# Altium schematic sheet F407_DISC.SchDoc(F407_DISC)
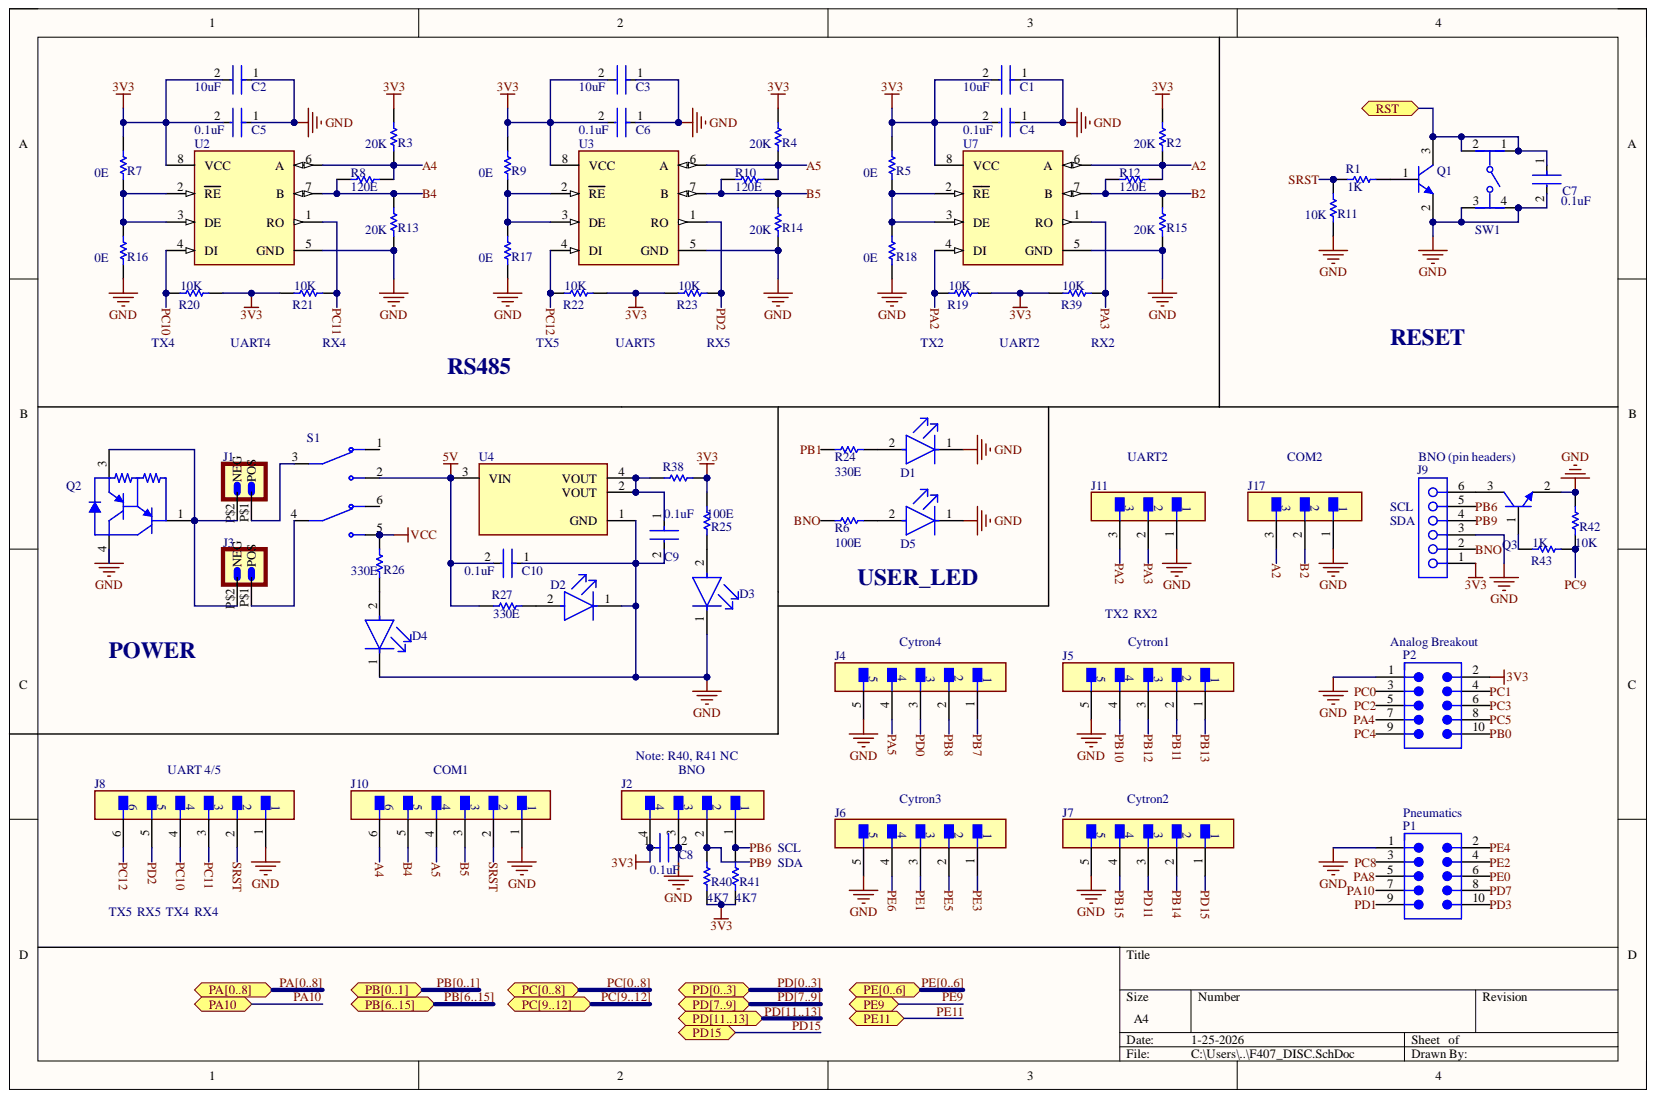
[diagram p0: F407_DISC.SchDoc]

## Parts
C1  CC0805KRX7R9BB104  [FP-CC0805-0_85-MFG]  (value not drawn)
C2  CC0805KRX7R9BB104  [FP-CC0805-0_85-MFG]  (value not drawn)
C3  CC0805KRX7R9BB104  [FP-CC0805-0_85-MFG]  (value not drawn)
C4  CC0805KRX7R9BB104  [FP-CC0805-0_85-MFG]  (value not drawn)
C5  CC0805KRX7R9BB104  [FP-CC0805-0_85-MFG]  (value not drawn)
C6  CC0805KRX7R9BB104  [FP-CC0805-0_85-MFG]  (value not drawn)
C7  CC0805KRX7R9BB104  [FP-CC0805-0_85-MFG]  (value not drawn)
C8  CC0805KRX7R9BB104  [FP-CC0805-0_85-MFG]  (value not drawn)
C9  CC0805KRX7R9BB104  [FP-CC0805-0_85-MFG]  (value not drawn)
C10  CC0805KRX7R9BB104  [FP-CC0805-0_85-MFG]  (value not drawn)
D1  HSMS-C150  [FP-HSMS-C150-MFG]  (value not drawn)
D2  HSMS-C150  [FP-HSMS-C150-MFG]  (value not drawn)
D3  HSMS-C150  [FP-HSMS-C150-MFG]  (value not drawn)
D4  HSMS-C150  [FP-HSMS-C150-MFG]  (value not drawn)
D5  HSMS-C150  [FP-HSMS-C150-MFG]  (value not drawn)
J1  XT30UPB-M  [XT30UPB-M]  (value not drawn)
J2  B4B-XH-A(LF)(SN)  [FP-B4B-XH-A_LF_SN-MFG]  (value not drawn)
J3  XT30UPB-M  [XT30UPB-M]  (value not drawn)
J4  B5B-XH-AM(LF)(SN)  [FP-B5B-XH-AM_LF_SN-MFG]  (value not drawn)
J5  B5B-XH-AM(LF)(SN)  [FP-B5B-XH-AM_LF_SN-MFG]  (value not drawn)
J6  B5B-XH-AM(LF)(SN)  [FP-B5B-XH-AM_LF_SN-MFG]  (value not drawn)
J7  B5B-XH-AM(LF)(SN)  [FP-B5B-XH-AM_LF_SN-MFG]  (value not drawn)
J8  B6B-XH-A(LF)(SN)  [FP-B6B-XH-A_LF_SN-MFG]  (value not drawn)
J9  61300611821  [61300611821]  (value not drawn)
J10  B6B-XH-A(LF)(SN)  [FP-B6B-XH-A_LF_SN-MFG]  (value not drawn)
J11  B3B-XH-AM(LF)(SN)  [FP-B3B-XH-AM_LF_SN-MFG]  (value not drawn)
J17  B3B-XH-AM(LF)(SN)  [FP-B3B-XH-AM_LF_SN-MFG]  (value not drawn)
P1  61201021621  [61201021621]  (value not drawn)
P2  61201021621  [61201021621]  (value not drawn)
Q1  MMBTA42LT1G  [ONSC-SOT-23-3-318-08_V]  (value not drawn)
Q2  MJD127G  [ONSC-DPAK-3-369C-01_V]  (value not drawn)
Q3  MMBTA42LT1G  [ONSC-SOT-23-3-318-08_V]  (value not drawn)
R1  RC0805FR-071KL  [RESC2013X60X35ML10T20]  (value not drawn)
R2  RC0805FR-071KL  [RESC2013X60X35ML10T20]  (value not drawn)
R3  RC0805FR-071KL  [RESC2013X60X35ML10T20]  (value not drawn)
R4  RC0805FR-071KL  [RESC2013X60X35ML10T20]  (value not drawn)
R5  RC0805FR-071KL  [RESC2013X60X35ML10T20]  (value not drawn)
R6  RC0805FR-071KL  [RESC2013X60X35ML10T20]  (value not drawn)
R7  RC0805FR-071KL  [RESC2013X60X35ML10T20]  (value not drawn)
R8  RC0805FR-071KL  [RESC2013X60X35ML10T20]  (value not drawn)
R9  RC0805FR-071KL  [RESC2013X60X35ML10T20]  (value not drawn)
R10  RC0805FR-071KL  [RESC2013X60X35ML10T20]  (value not drawn)
R11  RC0805FR-071KL  [RESC2013X60X35ML10T20]  (value not drawn)
R12  RC0805FR-071KL  [RESC2013X60X35ML10T20]  (value not drawn)
R13  RC0805FR-071KL  [RESC2013X60X35ML10T20]  (value not drawn)
R14  RC0805FR-071KL  [RESC2013X60X35ML10T20]  (value not drawn)
R15  RC0805FR-071KL  [RESC2013X60X35ML10T20]  (value not drawn)
R16  RC0805FR-071KL  [RESC2013X60X35ML10T20]  (value not drawn)
R17  RC0805FR-071KL  [RESC2013X60X35ML10T20]  (value not drawn)
R18  RC0805FR-071KL  [RESC2013X60X35ML10T20]  (value not drawn)
R19  RC0805FR-071KL  [RESC2013X60X35ML10T20]  (value not drawn)
R20  RC0805FR-071KL  [RESC2013X60X35ML10T20]  (value not drawn)
R21  RC0805FR-071KL  [RESC2013X60X35ML10T20]  (value not drawn)
R22  RC0805FR-071KL  [RESC2013X60X35ML10T20]  (value not drawn)
R23  RC0805FR-071KL  [RESC2013X60X35ML10T20]  (value not drawn)
R24  RC0805FR-071KL  [RESC2013X60X35ML10T20]  (value not drawn)
R25  RC0805FR-071KL  [RESC2013X60X35ML10T20]  (value not drawn)
R26  RC0805FR-071KL  [RESC2013X60X35ML10T20]  (value not drawn)
R27  RC0805FR-071KL  [RESC2013X60X35ML10T20]  (value not drawn)
R38  RC0805FR-071KL  [RESC2013X60X35ML10T20]  (value not drawn)
R39  RC0805FR-071KL  [RESC2013X60X35ML10T20]  (value not drawn)
R40  RC0805FR-071KL  [RESC2013X60X35ML10T20]  (value not drawn)
R41  RC0805FR-071KL  [RESC2013X60X35ML10T20]  (value not drawn)
R42  RC0805FR-071KL  [RESC2013X60X35ML10T20]  (value not drawn)
R43  RC0805FR-071KL  [RESC2013X60X35ML10T20]  (value not drawn)
S1  TL2285EE  [SW_TL2285EE]  (value not drawn)
SW1  1571634-2  [FP-1571634-2-MFG]  (value not drawn)
U2  MAX485ESA+T  [FP-S8-2-MFG]  (value not drawn)
U3  MAX485ESA+T  [FP-S8-2-MFG]  (value not drawn)
U4  LD1117S33CTR  [FP-SOT-223-0046067_14-MFG]  (value not drawn)
U7  MAX485ESA+T  [FP-S8-2-MFG]  (value not drawn)

## Ports
PA0, PA1, PA2, PA3, PA4, PA5, PA6, PA7, PA8, PA10, PA[0..8], PB0, PB1, PB6, PB7, PB8, PB9, PB10, PB11, PB12, PB13, PB14, PB15, PB[0..1], PB[6..15], PC0, PC1, PC2, PC3, PC4, PC5, PC6, PC7, PC8, PC9, PC10, PC11, PC12, PC[0..8], PC[9..12], PD0, PD1, PD2, PD3, PD7, PD8, PD9, PD11, PD12, PD13, PD15, PD[0..3], PD[7..9], PD[11..13], PE0, PE1, PE2, PE3, PE4, PE5, PE6, PE9, PE11, PE[0..6], RST

## Nets
3V3: C1.2 C2.2 C3.2 C4.2 C5.2 C6.2 C8.1 J2.4 J9.1 P2.2 R2.1 R3.1 R4.1 R5.2 R7.2 R9.2 R19.1 R20.1 R21.2 R22.1 R23.2 R25.1 R38.2 R39.2 R40.1 R41.1 U2.8(VCC) U3.8(VCC) U7.8(VCC)
5V: C10.2 R27.1 S1.2 U4.3(VIN)
GND: C1.1 C2.1 C3.1 C4.1 C5.1 C6.1 C7.2 C8.2 C9.2 C10.1 D1.1 D2.1 D3.1 D4.1 D5.1 J2.3 J4.5 J5.5 J6.5 J7.5 J8.1 J9.3 J10.1 J11.1 J17.1 P1.1 P2.1 Q1.2 Q2.4 Q3.2 R11.1 R13.2 R14.2 R15.2 R16.1 R17.1 R18.1 R42.1 SW1.3 SW1.4 U2.5(GND) U3.5(GND) U4.1(GND) U7.5(GND)
VCC: R26.2 S1.5
A2: J17.3 R2.2 R12.1 U7.6(A)
A4: J10.6 R3.2 R8.1 U2.6(A)
A5: J10.4 R4.2 R10.1 U3.6(A)
B2: J17.2 R12.2 R15.1 U7.7(B)
B4: J10.5 R8.2 R13.1 U2.7(B)
B5: J10.3 R10.2 R14.1 U3.7(B)
BNO: J9.2 R6.1
PA2: J11.3 R19.2 U7.4(DI) ; port PA[0..8]  [also labelled PA]
PA3: J11.2 R39.1 U7.1(RO) ; port PA[0..8]  [also labelled PA]
PA4: P2.7 ; port PA[0..8]  [also labelled PA]
PA5: J4.4 ; port PA[0..8]  [also labelled PA]
PA8: P1.5 ; port PA[0..8]  [also labelled PA]
PA10: P1.7 ; port PA10
PB0: P2.10 ; port PB[0..1]  [also labelled PB]
PB1: R24.1 ; port PB[0..1]  [also labelled PB]
PB6: J2.1 J9.5 R41.2 ; port PB[6..15]  [also labelled PB]
PB7: J4.1 ; port PB[6..15]  [also labelled PB]
PB8: J4.2 ; port PB[6..15]  [also labelled PB]
PB9: J2.2 J9.4 R40.2 ; port PB[6..15]  [also labelled PB]
PB10: J5.4 ; port PB[6..15]  [also labelled PB]
PB11: J5.2 ; port PB[6..15]  [also labelled PB]
PB12: J5.3 ; port PB[6..15]  [also labelled PB]
PB13: J5.1 ; port PB[6..15]  [also labelled PB]
PB14: J7.2 ; port PB[6..15]  [also labelled PB]
PB15: J7.4 ; port PB[6..15]  [also labelled PB]
PC0: P2.3 ; port PC[0..8]  [also labelled PC]
PC1: P2.4 ; port PC[0..8]  [also labelled PC]
PC2: P2.5 ; port PC[0..8]  [also labelled PC]
PC3: P2.6 ; port PC[0..8]  [also labelled PC]
PC4: P2.9 ; port PC[0..8]  [also labelled PC]
PC5: P2.8 ; port PC[0..8]  [also labelled PC]
PC8: P1.3 ; port PC[0..8]  [also labelled PC]
PC9: R42.2 R43.1 ; port PC[9..12]  [also labelled PC]
PC10: J8.4 R20.2 U2.4(DI) ; port PC[9..12]  [also labelled PC]
PC11: J8.3 R21.1 U2.1(RO) ; port PC[9..12]  [also labelled PC]
PC12: J8.6 R22.2 U3.4(DI) ; port PC[9..12]  [also labelled PC]
PD0: J4.3 ; port PD[0..3]  [also labelled PD]
PD1: P1.9 ; port PD[0..3]  [also labelled PD]
PD2: J8.5 R23.1 U3.1(RO) ; port PD[0..3]  [also labelled PD]
PD3: P1.10 ; port PD[0..3]  [also labelled PD]
PD7: P1.8 ; port PD[7..9]  [also labelled PD]
PD11: J7.3 ; port PD[11..13]  [also labelled PD]
PD15: J7.1 ; port PD15
PE0: P1.6 ; port PE[0..6]  [also labelled PE]
PE1: J6.3 ; port PE[0..6]  [also labelled PE]
PE2: P1.4 ; port PE[0..6]  [also labelled PE]
PE3: J6.1 ; port PE[0..6]  [also labelled PE]
PE4: P1.2 ; port PE[0..6]  [also labelled PE]
PE5: J6.2 ; port PE[0..6]  [also labelled PE]
PE6: J6.4 ; port PE[0..6]  [also labelled PE]
RST: C7.1 Q1.3 SW1.1 SW1.2 ; port RST
SRST: J8.2 J10.2 R1.1 R11.2
NetC9_1: C9.1 R38.1 U4.2(VOUT) U4.4(VOUT)
NetD1_2: D1.2 R24.2
NetD2_2: D2.2 R27.2
NetD3_2: D3.2 R25.2
NetD4_2: D4.2 R26.1
NetD5_2: D5.2 R6.2
NetJ1_P$1: J1.P$1 S1.3
NetJ1_P$2: J1.P$2 J3.P$2 Q2.1 Q2.3
NetJ3_P$1: J3.P$1 S1.4
NetJ9_6: J9.6 Q3.3
NetQ1_1: Q1.1 R1.2
NetQ3_1: Q3.1 R43.2
NetR5_1: R5.1 R18.2 U7.2(RE) U7.3(DE)
NetR7_1: R7.1 R16.2 U2.2(RE) U2.3(DE)
NetR9_1: R9.1 R17.2 U3.2(RE) U3.3(DE)
PA0: ; port PA[0..8]  [also labelled PA]
PA1: ; port PA[0..8]  [also labelled PA]
PA6: ; port PA[0..8]  [also labelled PA]
PA7: ; port PA[0..8]  [also labelled PA]
PB[0..1]: ; port PB[0..1]  [also labelled PB]
PB[6..15]: ; port PB[6..15]  [also labelled PB]
PC6: ; port PC[0..8]  [also labelled PC]
PC7: ; port PC[0..8]  [also labelled PC]
PC[0..8]: ; port PC[0..8]  [also labelled PC]
PC[9..12]: ; port PC[9..12]  [also labelled PC]
PD8: ; port PD[7..9]  [also labelled PD]
PD9: ; port PD[7..9]  [also labelled PD]
PD12: ; port PD[11..13]  [also labelled PD]
PD13: ; port PD[11..13]  [also labelled PD]
PD[0..3]: ; port PD[0..3]  [also labelled PD]
PD[7..9]: ; port PD[7..9]  [also labelled PD]
PD[11..13]: ; port PD[11..13]  [also labelled PD]
PE9: ; port PE9
PE11: ; port PE11

Not connected: S1 1 6

## Library data of the parts
C1-C10 = CMP-2100-03606-3: CAP CER 0.1UF 50V X7R 0805; Manufacturer: Yageo; Capacitance: 100 nF; Material: Ceramic; Tolerance: 0.1; Value: 100 nF; Automotive: No; Category: Cap; Component Kind: Standard; ComponentLink2Description: Datasheet; ComponentLink2URL: http://www.yageo.com/documents/recent/UPY-GPHC_Y5V_6.3V-to-50V_8.pdf; Device Class L1: Passive Components; Device Class L2: Capacitors; Device Class L3: Ceramic Capacitors; Digikey Description: CAP CER 0.1UF 50V X7R 0805; Footprint Url: http://www.yageo.com/exep/pages/download/literatures/UPY-C_GEN_24.pdf; Height: 0.95mm; Ipc Land Pattern Name: CAPC200125X85; Lead Free: Yes; Library Name: Altium Content Vault; Manufacturer Part Number: CC0805KRX7R9BB104; Mouser Description: Multilayer Ceramic Capacitors MLCC - SMD/SMT 100nF 50V X7R 10%; Octopart: https://octopart.com/cc0805krx7r9bb104-yageo-192993; Package: CC0805-0.85; RoHS: TRUE; Temperature: -30°C to +85°C; Temperature Characteristic: X7R; Temperature Range High: +85°C; Temperature Range Low: -30°C; Voltage Rating: 50 V
D1-D5 = CMP-21615-000001-1: LED RED DIFFUSED CHIP SMD; Dominant Wavelength: 626nm; Forward Current: 20mA; Forward Voltage: 2.1V; Lens Color: White; Lens Style: Rectangular; Luminous Intensity: 10mcd; Number of Elements: 1; Power Dissipation: 65mW; Reverse Voltage: 5V; Viewing Angle: 170°; Case Code (Imperial): 1206; Case Code (Metric): 3216; Case/Package: 1206; Color: Red; Component Kind: Standard; Depth: 1.6mm; Height: 1.1mm; Illumination Color: Red; Lead Free: Lead Free; Length: 3.2mm; Lens Transparency: Diffused; Library Name: Altium Content Vault; Max Operating Temperature: 85°C; Min Operating Temperature: -40°C; Mount: Surface Mount; Number of LEDs: 1; Number of Pins: 2; Package Quantity: 1; Packaging: Tape and Reel; Power Rating: 65mW; Radiation Hardening: No; REACH SVHC: No SVHC; Test Current: 20mA; Wavelength: 626nm; Width: 1.6mm
J1 J3 = XT30UPB-M: Socket; DC supply; XT30; male; PIN: 2; on PCBs; THT; yellow; 15A; 500V; MF: amass; Availability: Not in stock; Check_prices: https://www.snapeda.com/parts/XT30UPB-M/AMASS/view-part/?ref=eda; Component Kind: Standard; Library Name: XT30UPB-M.SchLib; MP: XT30UPB-M; Package: None; Price: None; SnapEDA_Link: https://www.snapeda.com/parts/XT30UPB-M/AMASS/view-part/?ref=snap
J2 = CMP-17439-000250-1: CONN HEADER VERT 4POS 2.5MM; Contact Resistance: 20mR; Current Rating: 3A; Gender: Male; Insulation Resistance: 1GR; Mated Stacking Heights: 9.8mm; Max Voltage Rating (AC): 250V; Number of Contacts: 4; Wire/Cable Gauge: 22AWG; Color: Natural; Component Kind: Standard; Connector Type: Header; Contact Finish - Mating: TIN; Contact Material: Brass; Contact Plating: Tin; Contact Shape: Square; Contact Type: Male Pin; Depth: 5.75mm; Fastening Type: Detent; Flammability Rating: UL94 V-0; Housing Color: White; Housing Material: Polyamide; Insulation Color: Natural; Insulation Material: Nylon; Lead Free: Lead Free; Lead Pitch: 2.5mm; Length: 12.4mm; Library Name: Altium Content Vault; Material: Polyamide; Max Current Rating: 3A; Max Operating Temperature: 85°C; Max Voltage Rating (DC): 250V; Min Operating Temperature: -25°C; Mount: Through Hole; Number of Positions: 4; Number of Rows: 1; Orientation: Straight; Package Quantity: 500; Packaging: Bulk; Pitch: 2.5mm; REACH SVHC: No SVHC; Series: XH; Termination: Solder; Voltage Rating: 250V; Voltage Rating (AC): 250V
J4-J7 = CMP-17439-000304-1: CONN HEADER VERT 5POS 2.5MM; Contact Resistance: 20mR; Mated Stacking Heights: 9.8mm; Max Current Rating: 3A; Max Voltage Rating (AC): 250V; Number of Positions: 5; Color: Green; Component Kind: Standard; Connector Type: Header; Contact Finish - Mating: TIN; Contact Material: Brass; Contact Plating: Copper; Contact Shape: Square; Contact Type: Male Pin; Depth: 5.75mm; Fastening Type: Detent; Flammability Rating: UL94 V-0; Housing Color: White; Housing Material: Polyamide; Insulation Color: Natural; Insulation Material: Nylon; Lead Free: Lead Free; Length: 14.9mm; Library Name: Altium Content Vault; Max Operating Temperature: 85°C; Min Operating Temperature: -25°C; Mount: Through Hole; Number of Rows: 1; Orientation: Straight; Packaging: Bulk; Pitch: 2.5mm; Series: XH; Termination: Solder; Voltage Rating: 250V
J8 J10 = CMP-17439-000076-1: CONN HEADER VERT 6POS 2.5MM; Component Kind: Standard; Library Name: Altium Content Vault
J9 = CMP-1502-01322-3: NexarPartId: 21642411; Contact Resistance: 20mΩ; Current Rating: 3A; Gender: Female; Operating Supply Voltage: 250V; Component Kind: Standard; Lead Pitch: 2.54mm; Library Name: Altium Content Vault; Number of Pins: 6; Orientation: Vertical; RoHS: Compliant
J11 J17 = CMP-17438-000009-1: CONN HEADER VERT 3POS 2.5MM; Connector Type: Male Pin; Contact Resistance: 20mR; Gender: Male; Max Current Rating: 3A; Max Voltage Rating (AC): 250V; Number of Positions: 3; Pitch: 0.098inch; Color: Natural; Component Kind: Standard; Contact Material: Brass; Contact Plating: Tin; Contact Type: Male Pin; Depth: 5.75mm; Fastening Type: Detent; Housing Color: White; Housing Material: Polyamide; Lead Free: Lead Free; Length: 9.9mm; Library Name: Altium Content Vault; Max Operating Temperature: 85°C; Min Operating Temperature: -25°C; Mount: Through Hole; Number of Rows: 1; Orientation: Straight; Packaging: Bulk; Series: XH; Termination: Press-Fit
P1 P2 = CMP-1502-00007-2: NexarPartId: 8917783; Connector Type: Male Pin; Contact Resistance: 20mΩ; Current Rating: 3A; Gender: Male; Insulation Resistance: 1GΩ; Number of Contacts: 10; Operating Supply Voltage: 250V; Pitch: 2.54mm; Color: Black; Component Kind: Standard; Contact Gender: Male; Contact Material: Copper; Contact Plating: Gold; Flammability Rating: UL94 V-0; Height: 8.85mm; Housing Material: PBT; Insulation Material: PBT; Lead Free: Lead Free; Lead Pitch: 2.54mm; Length: 20.36mm; Library Name: Altium Content Vault; Max Operating Temperature: 105°C; Min Operating Temperature: -40°C; Mount: Through Hole; Number of Pins: 10; Number of Positions: 10; Number of Rows: 2; Orientation: Vertical; REACH SVHC: Unknown; RoHS: Compliant; Row Spacing: 2.54mm; Termination: Solder; Voltage Rating: 250V; Voltage Rating (DC): 250V; Width: 20.36mm
Q1 Q3 = CMP-1048-00662-1: High Voltage Transistor, NPN Silicon, 3-Pin SOT-23, Pb-Free, Tape and Reel; Manufacturer: On Semiconductor; fT Min (MHz): 50; IC Continuous (A): 0.5; Component Kind: Standard; ComponentLink1Description: Manufacturer URL; ComponentLink1URL: http://www.onsemi.com/; ComponentLink2Description: Datasheet; ComponentLink2URL: http://www.onsemi.cn/pub_link/Collateral/MMBTA42LT1-D.PDF; ComponentLink3Description: Package Specification; ComponentLink3URL: http://www.onsemi.cn/pub_link/Collateral/318-08.PDF; DatasheetVersion: Rev. 12; Library Name: Altium Content Vault; Mounting Technology: Surface Mount; PackageDescription: 3-Pin Small Outline Transistor, Body 2.92 x 1.3 mm, Pitch 0.95 mm; PackageReference: SOT-23-3-318-08; PackageVersion: Rev. AP, 11/2009; Packing: Tape and Reel; PartNumber: MMBTA42LT1G; Polarity: NPN; RoHS: TRUE
Q2 = CMP-1047-00005-1: Complementary Darlington Power Transistor, 3-Pin DPAK, Pb-Free, Tube; Manufacturer: On Semiconductor; hFE Max (k): 12; IC Continuous (A): 8; Polarity: PNP; Component Kind: Standard; ComponentLink1Description: Manufacturer URL; ComponentLink1URL: http://www.onsemi.com/; ComponentLink2Description: Datasheet; ComponentLink2URL: http://www.onsemi.com/pub_link/Collateral/MJD122-D.PDF; ComponentLink3Description: Package Specification; ComponentLink3URL: http://www.onsemi.cn/pub_link/Collateral/369C.PDF; DatasheetVersion: Rev. 13; Library Name: Altium Content Vault; Mounting Technology: Surface Mount; PackageDescription: 3-Pin Flange Mount, Body 6.54 x 6.095 mm, Pitch 2.29 mm; PackageReference: DPAK-3-369C-01; PackageVersion: Rev. E, 02/2014; Packing: Tube; PartNumber: MJD127G; RoHS: TRUE
R1-R27 R38-R43 = CMP-2100-03671-1: Chip Resistor, 1 KOhm, +/- 1%, 125 mW, -55 to 155 degC, 0805 (2012 Metric), RoHS, Tape and Reel; Manufacturer: Yageo; Max Power Dissipation: 125mW; Resistance: 1KOhm; Temperature Coefficient: 100ppm/degreesC; Voltage Rating: 150V; Case Code (Imperial): 0805; Case Code (Metric): 2012; Case/Package: 0805; Component Kind: Standard; Composition: ThickFilm; Datasheet URL: http://www.yageo.com/NewPortal/yageodocoutput?fileName=/pdf/R-Chip/PYu-RC_Group_51_RoHS_L_5.pdf; Datasheet Version: Rev. 5, 10/2016; Depth: 1.25mm; Height: 0.024inch; Lead Free: LeadFree; Length: 0.079inch; Library Name: Altium Content Vault; Manufacturer URL: http://www.yageo.com/; Max Operating Temperature: 155degC; Military Standard: Not; Min Operating Temperature: -55degC; Number of Pins: 2; Number of Terminations: 2; Package Description: 2-Pin Surface Mounted Device, Body 2 x 1.25 mm; Package Quantity: 1; Package Reference: 0805; Packaging: TapeandReel; Power Rating: 125mW; REACH SVHC: Unknown; RoHSCompliant: true; Termination: SMD/SMT; Tolerance: 1%; Voltage Rating (DC): 150V; Width: 0.049inch
SW1 = CMP-03407-000008-1: SWITCH TACTILE SPST-NO 0.05A 24V; Actuator Color: Natural; Actuator Length: 0.094inch; Actuator Material: Rubber; Actuator Type: Standard; Contact Current Rating: 50mA; Illumination Type: Illuminated; Max Voltage Rating (DC): 12V; Mechanical Life: 5000000Cycles; Operating Force: 160gf; Switch Function: Off-Mom; Throw Configuration: SPST; Case/Package: SMD/SMT; Component Kind: Standard; Contact Material: Stainless Steel; Contact Plating: Silver; Current Rating: 50mA; Depth: 10mm; ELV: Compliant; Lead Free: Lead Free; Length: 6.2mm; Library Name: Altium Content Vault; Max Current Rating: 50mA; Max Operating Temperature: 85°C; Min Operating Temperature: -40°C; Mount: Surface Mount; Orientation: Straight; Package Quantity: 1000; Packaging: Tape and Reel; Radiation Hardening: No; RoHS Compliant: Yes; Termination: Gull Wing; Type: Switch; Voltage Rating (DC): 12V
U2 U3 U7 = CMP-05679-000009-1: IC TRANSCEIVER HALF 1/1 8SOIC; Manufacturer: Maxim Integrated; Data Rate: 2.5Mbits/s; Interface: RS-485,RS-422; Max Supply Voltage: 5.25V; Min Supply Voltage: 4.75V; Nominal Supply Current: 0.5mA; Number Of Receivers: 1; Simplex Duplex: Half-Duplex; Standoff Height: 0.1mm; Automotive: No; Category: IC; Component Kind: Standard; ComponentLink2Description: Datasheet; ComponentLink2URL: https://datasheets.maximintegrated.com/en/ds/MAX1487-MAX491.pdf; Device Class L1: Integrated Circuits (ICs); Device Class L2: Interface ICs; Device Class L3: RS-232 / RS-422 / RS-485 Interface ICs; Digikey Description: IC TRANSCEIVER HALF 1/1 8SOIC; Footprint Url: https://pdfserv.maximintegrated.com/land_patterns/90-0096.PDF; Height: 1.75mm; Ipc Land Pattern Name: SOIC127P600X155-8; Lead Free: Yes; Library Name: Altium Content Vault; Manufacturer Part Number: MAX485ESA+T; Mouser Description: RS-422/RS-485 Interface IC RS-485/RS-422 Transceiver; Number Of Transmitters: 1; Octopart: https://octopart.com/max485esa%2Bt-maxim+integrated-40011239; Package: S8-2; RoHS: TRUE; Temperature: -40°C to +85°C; Temperature Range High: +85°C; Temperature Range Low: -40°C
U4 = CMP-0244-00307-2: IC REG LINEAR 3.3V 800MA SOT223; Accuracy: 1%; Dropout Voltage: 1.1V; Input Bias Current: 5mA; Max Input Voltage: 15V; Max Output Current: 1.3A; Max Power Dissipation: 12W; Min Input Voltage: 5.3V; Number of Outputs: 1; Output Current: 950mA; Output Type: Fixed; Polarity: Positive; Quiescent Current: 10mA; Case/Package: SOT-223; Component Kind: Standard; Contact Plating: Tin; Height: 1.8mm; Lead Free: Lead Free; Length: 6.5mm; Library Name: Altium Content Vault; Max Operating Temperature: 125°C; Max Output Voltage: 3.3V; Max Supply Voltage: 15V; Min Operating Temperature: -40°C; Min Output Voltage: 3.3V; Min Supply Voltage: 3.3V; Mount: Surface Mount; Nominal Output Voltage: 3.3V; Number of Pins: 4; Number of Regulators: 1; Number of Terminals: 4; Output Voltage: 3.3V; Output Voltage Accuracy: 1%; Package Quantity: 1; Packaging: Tape and Reel; Power Dissipation: 12W; Radiation Hardening: No; REACH SVHC: No SVHC; Termination: SMD/SMT; Weight: 0.01lb; Width: 3.5mm
S1 = TL2285EE: Availability: Unavailable; Component Kind: Standard; Library Name: TL2285EE.SchLib; MP: TL2285EE; Package: None; Price: None
